# Altium schematic sheet Sheet5.SchDoc(Sheet5)
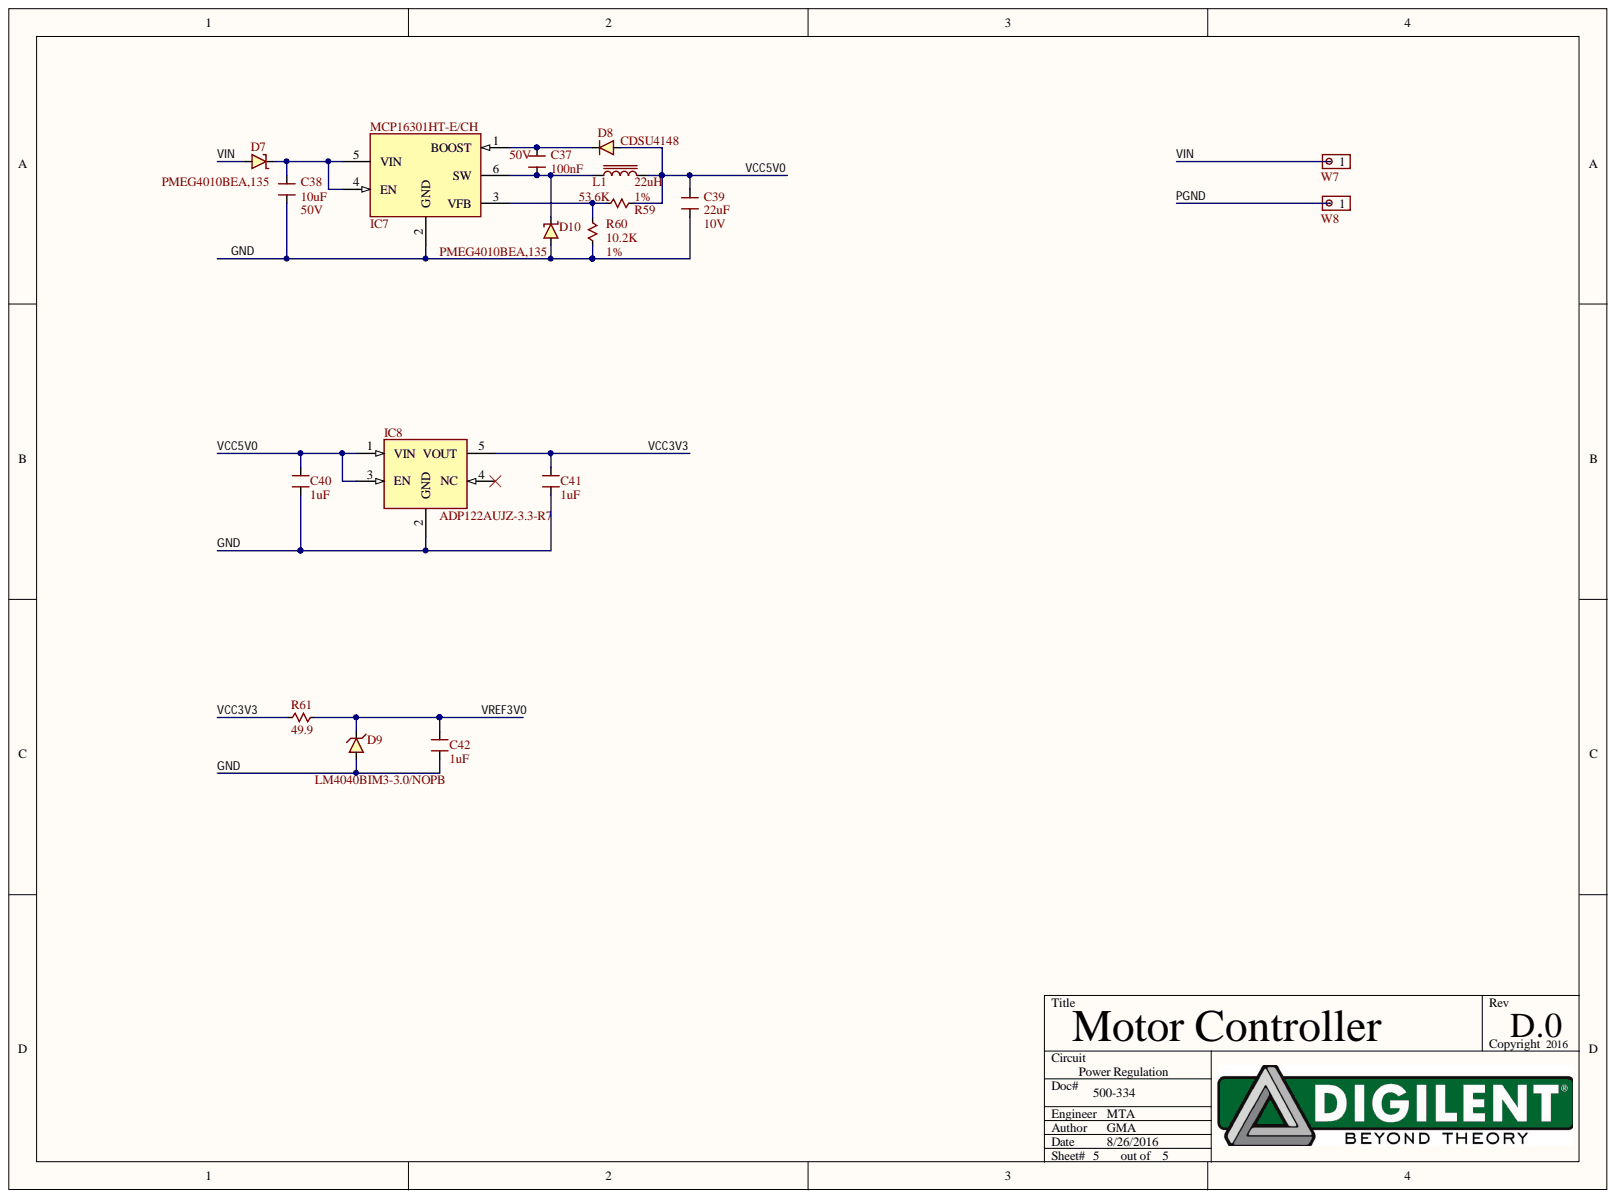
[diagram p0: Sheet5.SchDoc]

## Parts
C37  50V  value 100nF  [CAP1210]
C38  50V  value 10uF  [CAP1206]
C39  10V  value 22uF  [CAP0805]
C40  value 1uF  [CAP0402]  (hidden comment: 10V)
C41  value 1uF  [CAP0402]  (hidden comment: CAPACITOR)
C42  value 1uF  [CAP0402]  (hidden comment: CAPACITOR)
D7  PMEG4010BEA,135  [SOD-323]
D8  CDSU4148  [D0603]
D9  LM4040BIM3-3.0/NOPB  [SOT23]
D10  PMEG4010BEA,135  [SOD-323]
IC7  MCP16301HT-E/CH  [SOT23-6]
IC8  ADP122AUJZ-3.3-R7  [SOT23-5]
L1  value 22uH  [SRN4018]  (hidden comment: SRN4018-220M)
R59  1%  value 53.6K  [RES0402]
R60  1%  value 10.2K  [RES0402]
R61  value 49.9  [RES0402]  (hidden comment: RESISTOR)
W7  12G Wire Red  [WLAND-SMD]  (value not drawn)
W8  12G Wire Black  [WLAND-SMD]  (value not drawn)

## Nets
GND: C38.2 C39.2 C40.2 C41.2 C42.2 D9.2 D10.A IC7.2(GND) IC8.2(GND) R60.1
PGND: W8.1
VCC3V3: C41.1 IC8.5(VOUT) R61.2
VCC5V0: C39.1 C40.1 D8.A IC8.1(VIN) IC8.3(EN) L1.2 R59.2
VIN: D7.A W7.1
VREF3V0: C42.1 D9.1 R61.1
NetC37_1: C37.1 D8.K IC7.1(BOOST)
NetC37_2: C37.2 D10.K IC7.6(SW) L1.1
NetC38_1: C38.1 D7.K IC7.4(EN) IC7.5(VIN)
NetIC7_3: IC7.3(VFB) R59.1 R60.2

Not connected: IC8 4(NC)

## Library data of the parts
C37-C42 = CAPACITOR: LoadStatus: Load; Component Kind: Standard; Description: Capacitor; Library Name: DigilentUN.IntLib
D7 D10 = DIODE-SCH: Schotkey Diode NOT GENERIC; Manufacturer: NXP; LoadStatus: Load; Component Kind: Standard; Library Name: DigilentUN.IntLib
D8 = DIODE: Surface Mount Schottky Rectifier; Manufacturer: Vishay; LoadStatus: Load; Component Kind: Standard; Library Name: DigilentUN.IntLib
D9 = LM4040: Zener Diode; LoadStatus: Load; Component Kind: Standard; Library Name: DigilentUN.IntLib
IC7 = MCP16301T: High Voltage Input Integrated Switch Step-Down Regulator; Manufacturer: Microchip; LoadStatus: Load; Component Kind: Standard; Library Name: DigilentUN.IntLib
IC8 = ADP122: 300mA, Low-Quiescent Current, CMOS Regulator 0.85V dropout; Manufacturer: Analog Devices; LoadStatus: Load; Component Kind: Standard; Library Name: DigilentUN.IntLib
L1 = Inductor: Manufacturer: Bourns; LoadStatus: Load; Component Kind: Standard; Description: Inductor; Library Name: DigilentUN.IntLib
R59-R61 = RESISTOR: LoadStatus: Load; Component Kind: Standard; Description: Resistor; Library Name: DigilentUN.IntLib
W7 W8 = WLAND-1X1: 42hil Hole for Wire soldering; Manufacturer: NA; LoadStatus: Load; Component Kind: Standard; Library Name: DigilentUN.IntLib
